# Altium schematic sheet Halloween_2019_rA0.SchDoc
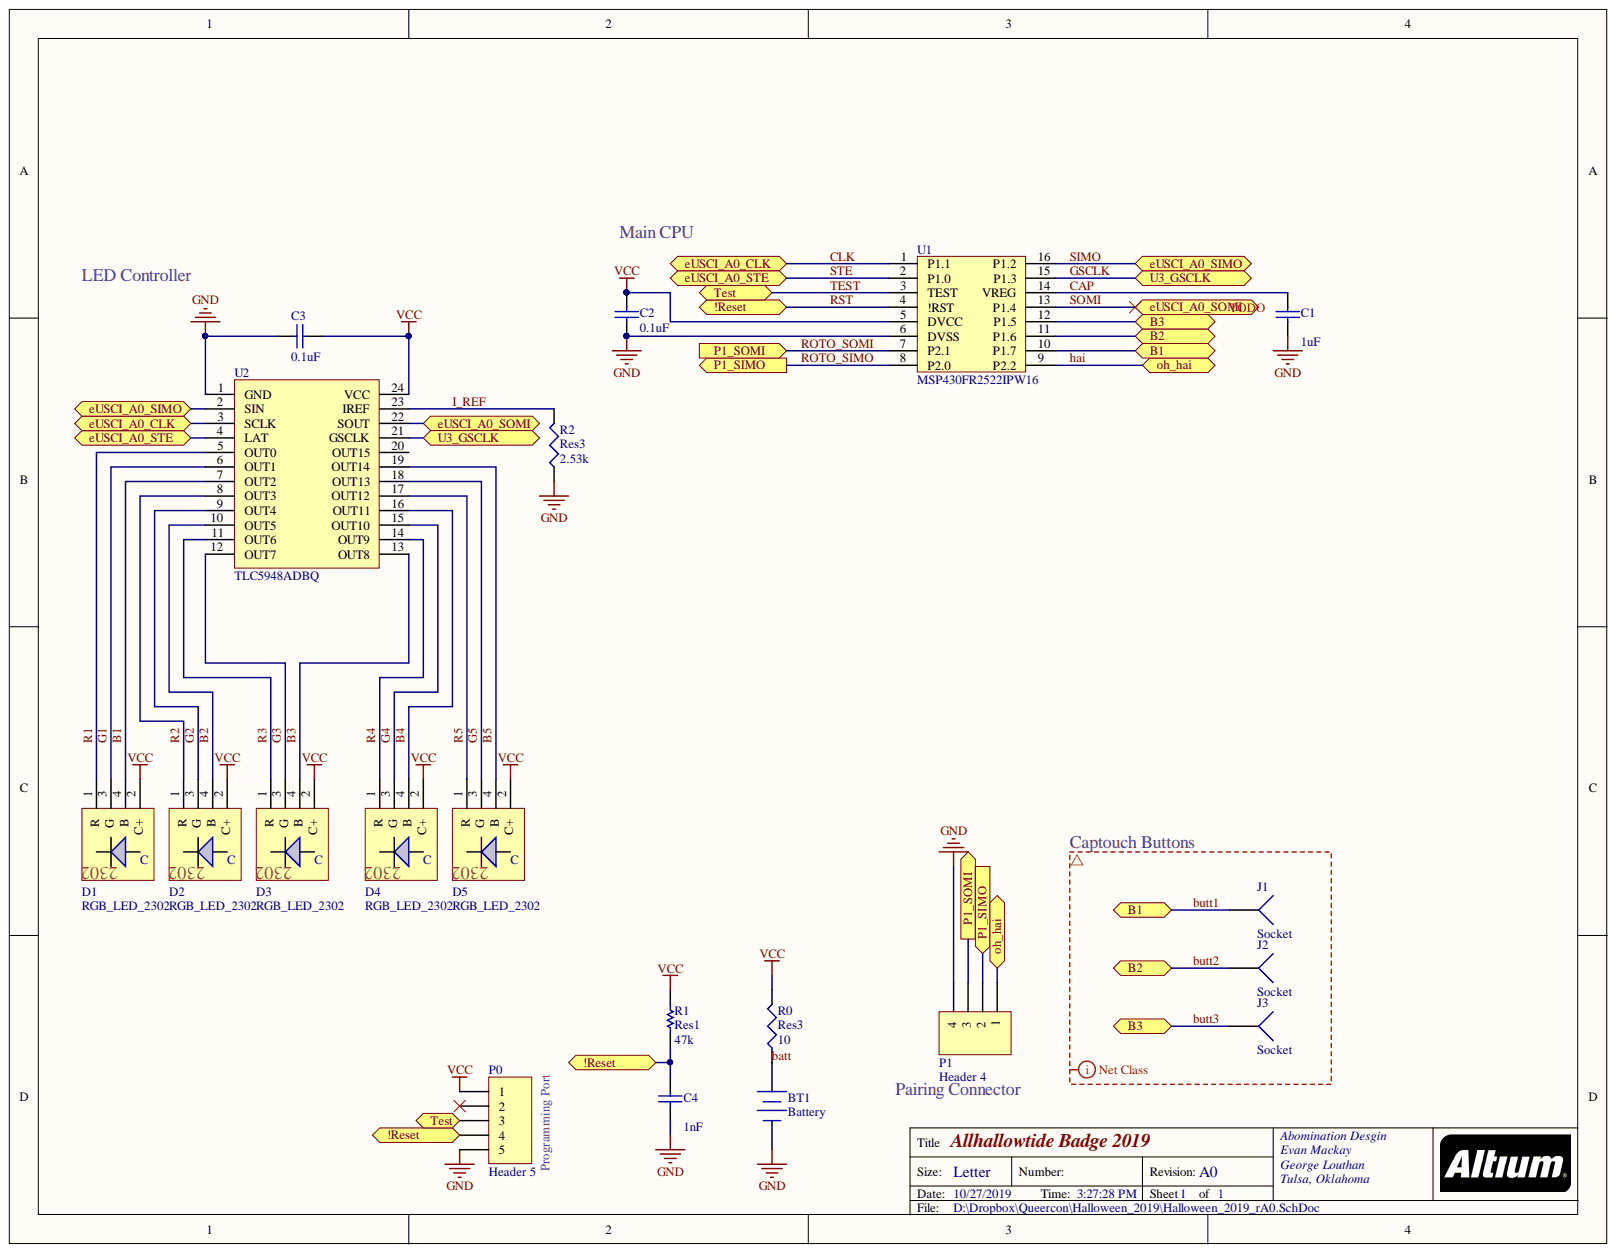
[diagram p0: Halloween_2019_rA0.SchDoc]

## Parts
BT1  Battery  [CR123A]
C1  value 1uF  [CAPC1608(0603)]
C2  value 0.1uF  [CAPC1608(0603)]  (hidden comment: Cap)
C3  value 0.1uF  [CAPC1608(0603)]  (hidden comment: Cap)
C4  value 1nF  [CAPC1608(0603)]
D1  RGB_LED_2302  [HQ67-2302RGBC]
D2  RGB_LED_2302  [HQ67-2302RGBC]
D3  RGB_LED_2302  [HQ67-2302RGBC]
D4  RGB_LED_2302  [HQ67-2302RGBC]
D5  RGB_LED_2302  [HQ67-2302RGBC]
J1  Socket  [captouch]
J2  Socket  [captouch]
J3  Socket  [captouch]
P0  Header 5  [Prog 2mm 5]
P1  Header 4  [RotaConnect]
R0  Res3  value 10  [J1-0603]
R1  Res1  value 47k  [RESC1608(0603)]
R2  Res3  value 2.53k  [J1-0603]
U1  MSP430FR2522IPW16  [TSSOP65P640X120-16N]
U2  TLC5948ADBQ  [TSOP65P640X120-24N]

## Ports
!Reset, B1, B2, B3, eUSCI_A0_CLK, eUSCI_A0_SIMO, eUSCI_A0_SOMI, eUSCI_A0_STE, oh_hai, P1_SIMO, P1_SOMI, Test, U3_GSCLK

## Nets
GND: BT1.2 C1.1 C2.2 C3.2 C4.1 P0.5 P1.4 R2.1 U1.6(DVSS) U2.1(GND)
VCC: C2.1 C3.1 D1.2(C+) D2.2(C+) D3.2(C+) D4.2(C+) D5.2(C+) P0.1 R0.2 R1.1 U1.5(DVCC) U2.24(VCC)
B1: D1.4(B) U2.7(OUT2)
B2: D2.4(B) U2.10(OUT5)
B3: D3.4(B) U2.13(OUT8)
B4: D4.4(B) U2.16(OUT11)
B5: D5.4(B) U2.19(OUT14)
batt: BT1.1 R0.1
butt1: J1.1 U1.10(P1.7) ; port B1
butt2: J2.1 U1.11(P1.6) ; port B2
butt3: J3.1 U1.12(P1.5) ; port B3
CAP: C1.2 U1.14(VREG)
CLK: U1.1(P1.1) U2.3(SCLK) ; port eUSCI_A0_CLK
G1: D1.3(G) U2.6(OUT1)
G2: D2.3(G) U2.9(OUT4)
G3: D3.3(G) U2.12(OUT7)
G4: D4.3(G) U2.15(OUT10)
G5: D5.3(G) U2.18(OUT13)
GSCLK: U1.15(P1.3) U2.21(GSCLK) ; port U3_GSCLK
hai: P1.1 U1.9(P2.2) ; port oh_hai
I_REF: R2.2 U2.23(IREF)
R1: D1.1(R) U2.5(OUT0)
R2: D2.1(R) U2.8(OUT3)
R3: D3.1(R) U2.11(OUT6)
R4: D4.1(R) U2.14(OUT9)
R5: D5.1(R) U2.17(OUT12)
ROTO_SIMO: P1.2 U1.8(P2.0) ; port P1_SIMO
ROTO_SOMI: P1.3 U1.7(P2.1) ; port P1_SOMI
RST: C4.2 P0.4 R1.2 U1.4(!RST) ; port !Reset
SIMO: U1.16(P1.2) U2.2(SIN) ; port eUSCI_A0_SIMO
SOMI: U1.13(P1.4) U2.22(SOUT) ; port eUSCI_A0_SOMI
STE: U1.2(P1.0) U2.4(LAT) ; port eUSCI_A0_STE
TEST: P0.3 U1.3(TEST) ; port Test
N00021:

Not connected: P0 2; U2 20(OUT15)

## Library data of the parts
BT1 = Battery: Multicell Battery; Component Kind: Standard; LatestRevisionDate: 17-Jul-2002; LatestRevisionNote: Re-released for DXP Platform.; Library Name: Miscellaneous Devices.IntLib; PackageDescription: Battery; 2 Leads; PackageReference: BAT-2; Published: 24-Mar-1999; Publisher: Altium Limited
C1-C4 = Cap: Capacitor; Component Kind: Standard; LatestRevisionDate: 17-Jul-2002; LatestRevisionNote: Re-released for DXP Platform.; Library Name: Miscellaneous Devices.IntLib; PackageDescription: Radial Cap, Thru-Hole; 2 Leads; 0.3 in Pin Spacing; PackageReference: RAD-0.3; Published: 8-Jun-2000; Signal Integrity: Cap; Simulation: CAP; Publisher: Altium Limited
P0 = Header 5: Header, 5-Pin; Component Kind: Standard; LatestRevisionDate: 17-Jul-2002; LatestRevisionNote: Re-released for DXP Platform.; Library Name: Miscellaneous Connectors.IntLib; Signal Integrity: Connector; Publisher: Altium Limited
P1 = Header 4: Header, 4-Pin; Component Kind: Standard; LatestRevisionDate: 17-Jul-2002; LatestRevisionNote: Re-released for DXP Platform.; Library Name: Miscellaneous Connectors.IntLib; Signal Integrity: Connector; Publisher: Altium Limited
R0 R2 = Res3: Resistor; Component Kind: Standard; LatestRevisionDate: 29-May-2009; LatestRevisionNote: IPC-7351 Footprint Added.; Library Name: Miscellaneous Devices.IntLib; PackageDescription: Chip Resistor; PackageReference: J1-0603; Published: 8-Jun-2000; Signal Integrity: Res; Simulation: RESISTOR; Publisher: Altium Limited
R1 = Res1: Resistor; Component Kind: Standard; LatestRevisionDate: 17-Jul-2002; LatestRevisionNote: Re-released for DXP Platform.; Library Name: Miscellaneous Devices.IntLib; PackageDescription: Axial Device, Thru-Hole; 2 Leads; 0.3 in Pin Spacing; PackageReference: AXIAL-0.3; Published: 8-Jun-2000; Signal Integrity: Res; Simulation: RESISTOR; Publisher: Altium Limited
U1 = Access Pass CPU: Component Kind: Standard; Library Name: Schlib1.SchLib
D1-D5 = RGB_LED_2302: Component Kind: Standard; Library Name: 2018SchematicLibrary.SchLib
J1-J3 = Socket: Component Kind: Standard; Description: Socket; LatestRevisionDate: 17-Jul-2002; LatestRevisionNote: Re-released for DXP Platform.; Library Name: Miscellaneous Connectors.IntLib; Signal Integrity: Connector; Publisher: Altium Limited
U2 = TLC5948ADBQ: Component Kind: Standard; Library Name: Lib_2016.SchLib
